# Altium schematic sheet Reserv.SchDoc(Reserv)
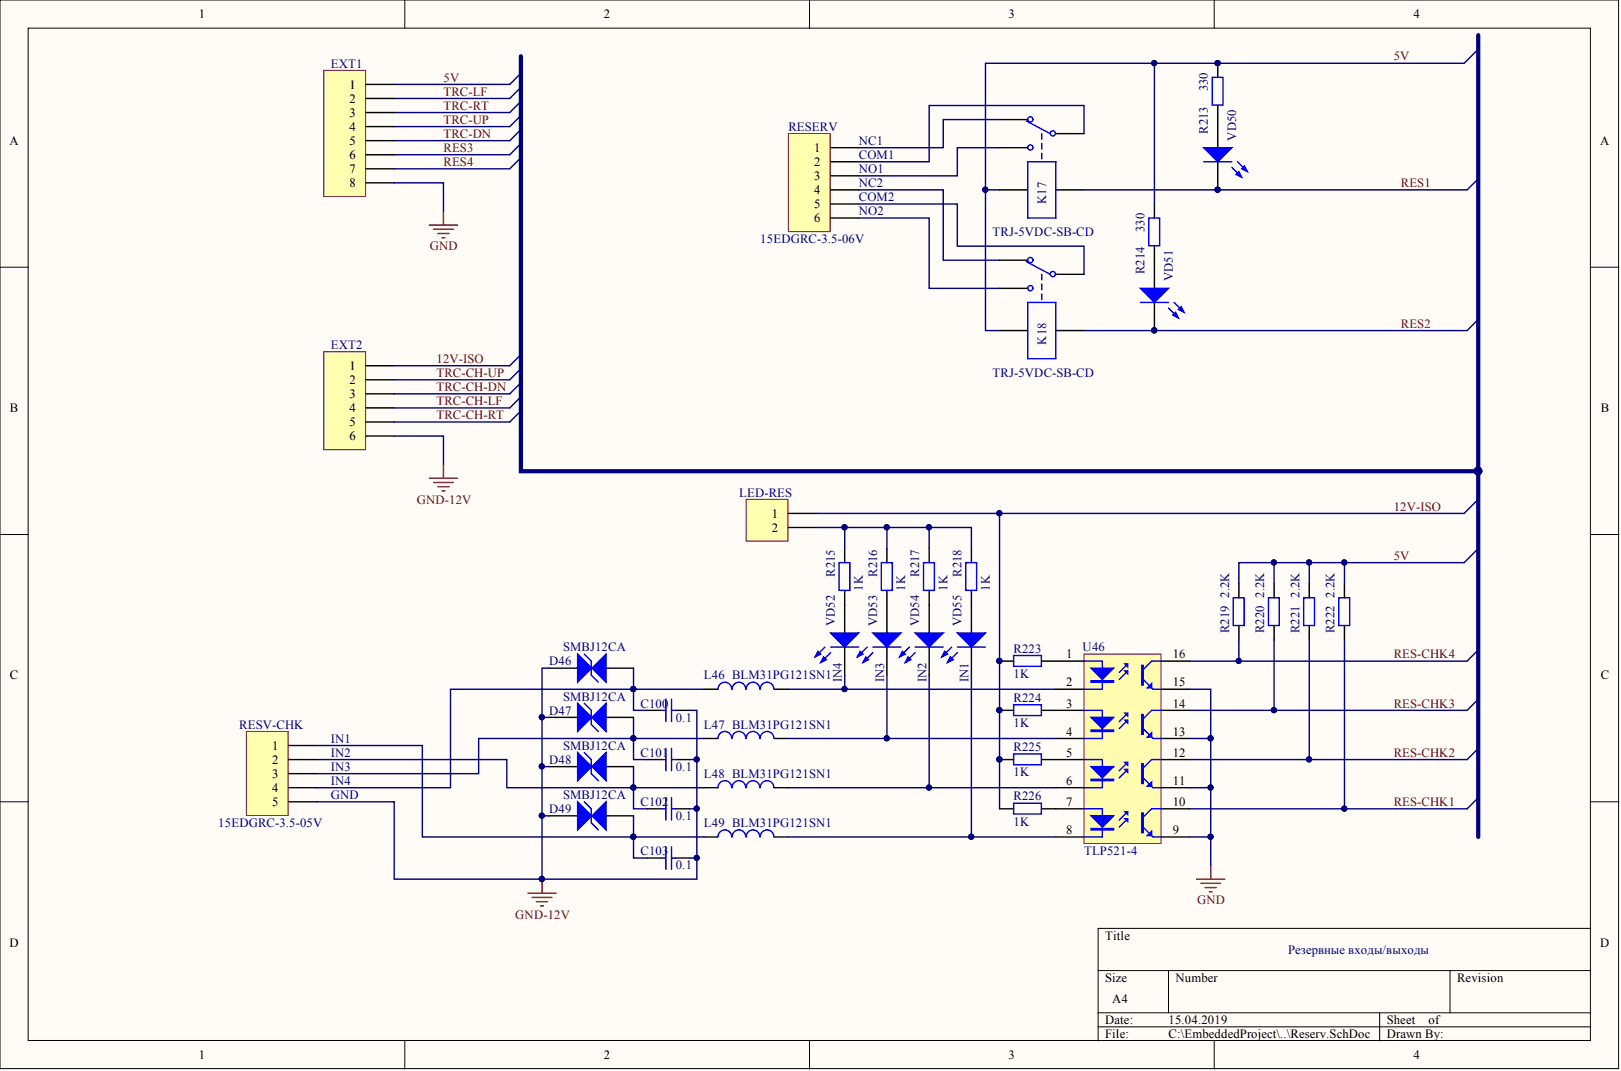
[diagram p0: Reserv.SchDoc]

## Parts
C100  value 0.1  [C1206]  (hidden comment: Cap)
C101  value 0.1  [C1206]  (hidden comment: Cap)
C102  value 0.1  [C1206]  (hidden comment: Cap)
C103  value 0.1  [C1206]  (hidden comment: Cap)
D46  SMBJ12CA  [DO-214AA]
D47  SMBJ12CA  [DO-214AA]
D48  SMBJ12CA  [DO-214AA]
D49  SMBJ12CA  [DO-214AA]
EXT1  Header 8  [HDR1X8F]  (value not drawn)
EXT2  Header 6  [HDR1X6F]  (value not drawn)
K17  value TRJ-5VDC-SB-CD  [TRJ-5VDC-SB-CD]  (hidden comment: Single-Pole Dual-Throw Relay)
K18  value TRJ-5VDC-SB-CD  [TRJ-5VDC-SB-CD]  (hidden comment: Single-Pole Dual-Throw Relay)
L46  value BLM31PG121SN1  [L1206]  (hidden comment: Ferrite Chip Beads)
L47  value BLM31PG121SN1  [L1206]  (hidden comment: Ferrite Chip Beads)
L48  value BLM31PG121SN1  [L1206]  (hidden comment: Ferrite Chip Beads)
L49  value BLM31PG121SN1  [L1206]  (hidden comment: Ferrite Chip Beads)
LED-RES  JP2  [HDR1X2]  (value not drawn)
R213  value 330  [1206]  (hidden comment: Res2)
R214  value 330  [1206]  (hidden comment: Res2)
R215  value 1K  [1206]  (hidden comment: Res2)
R216  value 1K  [1206]  (hidden comment: Res2)
R217  value 1K  [1206]  (hidden comment: Res2)
R218  value 1K  [1206]  (hidden comment: Res2)
R219  value 2.2K  [1206]  (hidden comment: Res2)
R220  value 2.2K  [1206]  (hidden comment: Res2)
R221  value 2.2K  [1206]  (hidden comment: Res2)
R222  value 2.2K  [1206]  (hidden comment: Res2)
R223  value 1K  [1206]  (hidden comment: Res2)
R224  value 1K  [1206]  (hidden comment: Res2)
R225  value 1K  [1206]  (hidden comment: Res2)
R226  value 1K  [1206]  (hidden comment: Res2)
RESERV  value 15EDGRC-3.5-06V  [15EDGRC-3.5-06V]  (hidden comment: Header, 6-Pin)
RESV-CHK  value 15EDGRC-3.5-05V  [15EDGRC-3.5-05V]  (hidden comment: Header, 5-Pin)
U46  TLP521-4  [DIP-16 - OPTO]
VD50  RES1  [LED1206 - RED]  (value not drawn)
VD51  RES2  [LED1206 - RED]  (value not drawn)
VD52  IN4  [LED1206 - YELOW]
VD53  IN3  [LED1206 - YELOW]
VD54  IN2  [LED1206 - YELOW]
VD55  IN1  [LED1206 - YELOW]

## Nets
GND: EXT1.8 U46.9 U46.11 U46.13 U46.15
GND-12V: C100.2 C101.2 C102.2 C103.2 D46.2 D47.2 D48.2 D49.2 EXT2.6 RESV-CHK.5
5V: EXT1.1 K17.5 K18.5 R213.1 R214.1 R219.1 R220.1 R221.1 R222.1
12V-ISO: EXT2.1 LED-RES.1 R223.1 R224.1 R225.1 R226.1
RES1: K17.4 VD50.2
RES2: K18.4 VD51.2
RES3: EXT1.6
RES4: EXT1.7
RES-CHK1: R222.2 U46.10
RES-CHK2: R221.2 U46.12
RES-CHK3: R220.2 U46.14
RES-CHK4: R219.2 U46.16
TRC-CH-DN: EXT2.3
TRC-CH-LF: EXT2.4
TRC-CH-RT: EXT2.5
TRC-CH-UP: EXT2.2
TRC-DN: EXT1.5
TRC-LF: EXT1.2
TRC-RT: EXT1.3
TRC-UP: EXT1.4
NetC100_1: C100.1 D46.1 L46.1 RESV-CHK.4
NetC101_1: C101.1 D47.1 L47.1 RESV-CHK.3
NetC102_1: C102.1 D48.1 L48.1 RESV-CHK.2
NetC103_1: C103.1 D49.1 L49.1 RESV-CHK.1
NetK17_1: K17.1 RESERV.2
NetK17_2: K17.2 RESERV.1
NetK17_3: K17.3 RESERV.3
NetK18_1: K18.1 RESERV.5
NetK18_2: K18.2 RESERV.4
NetK18_3: K18.3 RESERV.6
NetL46_2: L46.2 U46.2 VD52.2
NetL47_2: L47.2 U46.4 VD53.2
NetL48_2: L48.2 U46.6 VD54.2
NetL49_2: L49.2 U46.8 VD55.2
NetLED-RES_2: LED-RES.2 R215.2 R216.2 R217.2 R218.2
NetR213_2: R213.2 VD50.1
NetR214_2: R214.2 VD51.1
NetR215_1: R215.1 VD52.1
NetR216_1: R216.1 VD53.1
NetR217_1: R217.1 VD54.1
NetR218_1: R218.1 VD55.1
NetR223_2: R223.2 U46.1
NetR224_2: R224.2 U46.3
NetR225_2: R225.2 U46.5
NetR226_2: R226.2 U46.7
N00627:

## Library data of the parts
C100-C103 = Cap: Capacitor; Component Kind: Standard; LatestRevisionDate: 17-Jul-2002; LatestRevisionNote: Re-released for DXP Platform.; Library Name: Miscellaneous Devices.IntLib; PackageReference: RAD-0.3; Published: 8-Jun-2000; Signal Integrity: Cap; Simulation: CAP; Publisher: Altium Limited
D46-D49 = SMBJ12CA: Voltage suppressor; Component Kind: Standard; Library Name: Schlib1.SchLib; Value: SMBJ12CA
EXT1 = Header 8: Header, 8-Pin; Component Kind: Standard; LatestRevisionDate: 17-Jul-2002; LatestRevisionNote: Re-released for DXP Platform.; Library Name: Miscellaneous Connectors.IntLib; Signal Integrity: Connector; Value: Header 8; Publisher: Altium Limited
EXT2 = Header 6: Header, 6-Pin; Component Kind: Standard; LatestRevisionDate: 17-Jul-2002; LatestRevisionNote: Re-released for DXP Platform.; Library Name: Miscellaneous Connectors.IntLib; Signal Integrity: Connector; VALUE: Header 6; Publisher: Altium Limited
K17 K18 = Relay-SPDT: Component Kind: Standard; Description: Single-Pole Dual-Throw Relay; LatestRevisionDate: 17-Jul-2002; LatestRevisionNote: Re-released for DXP Platform.; Library Name: Miscellaneous Devices.IntLib; PackageReference: MODULE5B; Published: 24-Mar-1999; Simulation: SPDTRELAY; Publisher: Altium Limited
L46-L49 = Inductor: Code_IEC: 1005; Code_JEITA: 0402; Component Kind: Standard; Description: Ferrite Chip Beads; LatestRevisionDate: 29-May-2009; LatestRevisionNote: IPC-7351 Footprint Added.; Library Name: Miscellaneous Devices.IntLib; PackageDescription: Chip Inductor; PackageReference: 0402-A; Published: 8-Jun-2000; Signal Integrity: Inductor; Simulation: INDUCTOR; Publisher: Altium Limited
LED-RES = Header 2: Header, 2-Pin; value: Jumper; Component Kind: Standard; LatestRevisionDate: 17-Jul-2002; LatestRevisionNote: Re-released for DXP Platform.; Library Name: Miscellaneous Connectors.IntLib; Signal Integrity: Connector; Publisher: Altium Limited
R213 R214 R216-R226 = Res2: Resistor; Component Kind: Standard; LatestRevisionDate: 17-Jul-2002; LatestRevisionNote: Re-released for DXP Platform.; Library Name: Miscellaneous Devices.IntLib; PackageReference: AXIAL-0.4; Published: 8-Jun-2000; Signal Integrity: Res; Simulation: RESISTOR; Publisher: Altium Limited
R215 = Res2: Resistor; Value: 1K; Component Kind: Standard; LatestRevisionDate: 17-Jul-2002; LatestRevisionNote: Re-released for DXP Platform.; Library Name: Miscellaneous Devices.IntLib; PackageReference: AXIAL-0.4; Published: 8-Jun-2000; Signal Integrity: Res; Simulation: RESISTOR; Publisher: Altium Limited
RESERV = Header 6: Component Kind: Standard; Description: Header, 6-Pin; LatestRevisionDate: 17-Jul-2002; LatestRevisionNote: Re-released for DXP Platform.; Library Name: Miscellaneous Connectors.IntLib; Signal Integrity: Connector; Publisher: Altium Limited
RESV-CHK = Header 5: Component Kind: Standard; Description: Header, 5-Pin; LatestRevisionDate: 17-Jul-2002; LatestRevisionNote: Re-released for DXP Platform.; Library Name: Miscellaneous Connectors.IntLib; Signal Integrity: Connector; Publisher: Altium Limited
U46 = TLP521-4: 4 consist of a photo−transistor; Component Kind: Standard; DatasheetVersion: Nov-2003; LatestRevisionDate: 04-Mar-2005; LatestRevisionNote: Sch pins 3 & 4 Swapped.; Library Name: Schlib1.SchLib; PackageReference: DIP-4; Published: 24-Mar-1999; value: TLP521-4; Publisher: Altium Limited
VD50 VD51 = LED0: SMD LED; value: RED; Component Kind: Standard; LatestRevisionDate: 08-Jul-2005; LatestRevisionNote: Mech Layer 1 removed.; Library Name: Miscellaneous Devices.IntLib; PackageReference: LED-0; Published: 8-Jun-2000; Simulation: LED0; Publisher: Altium Limited
VD52-VD55 = LED0: SMD LED; value: ORANGE; Component Kind: Standard; LatestRevisionDate: 08-Jul-2005; LatestRevisionNote: Mech Layer 1 removed.; Library Name: Miscellaneous Devices.IntLib; PackageReference: LED-0; Published: 8-Jun-2000; Simulation: LED0; Publisher: Altium Limited
